# Altium schematic sheet Z27 - LED Digit Board.SchDoc("Z27 - LED Digit Board")
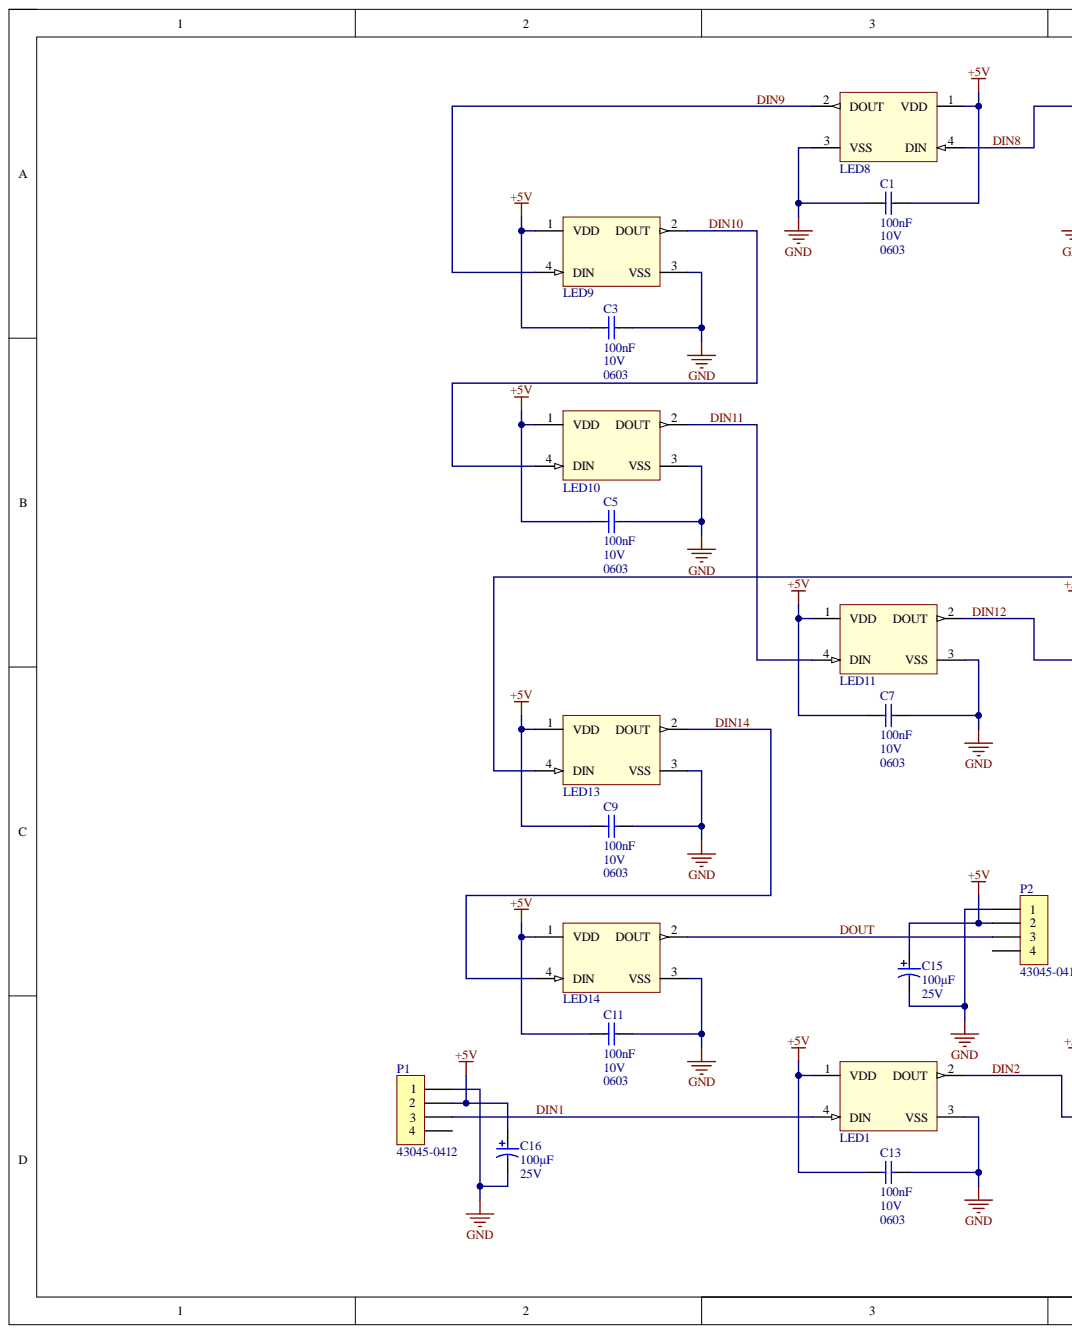
[diagram p0: Z27 - LED Digit Board.SchDoc, left]
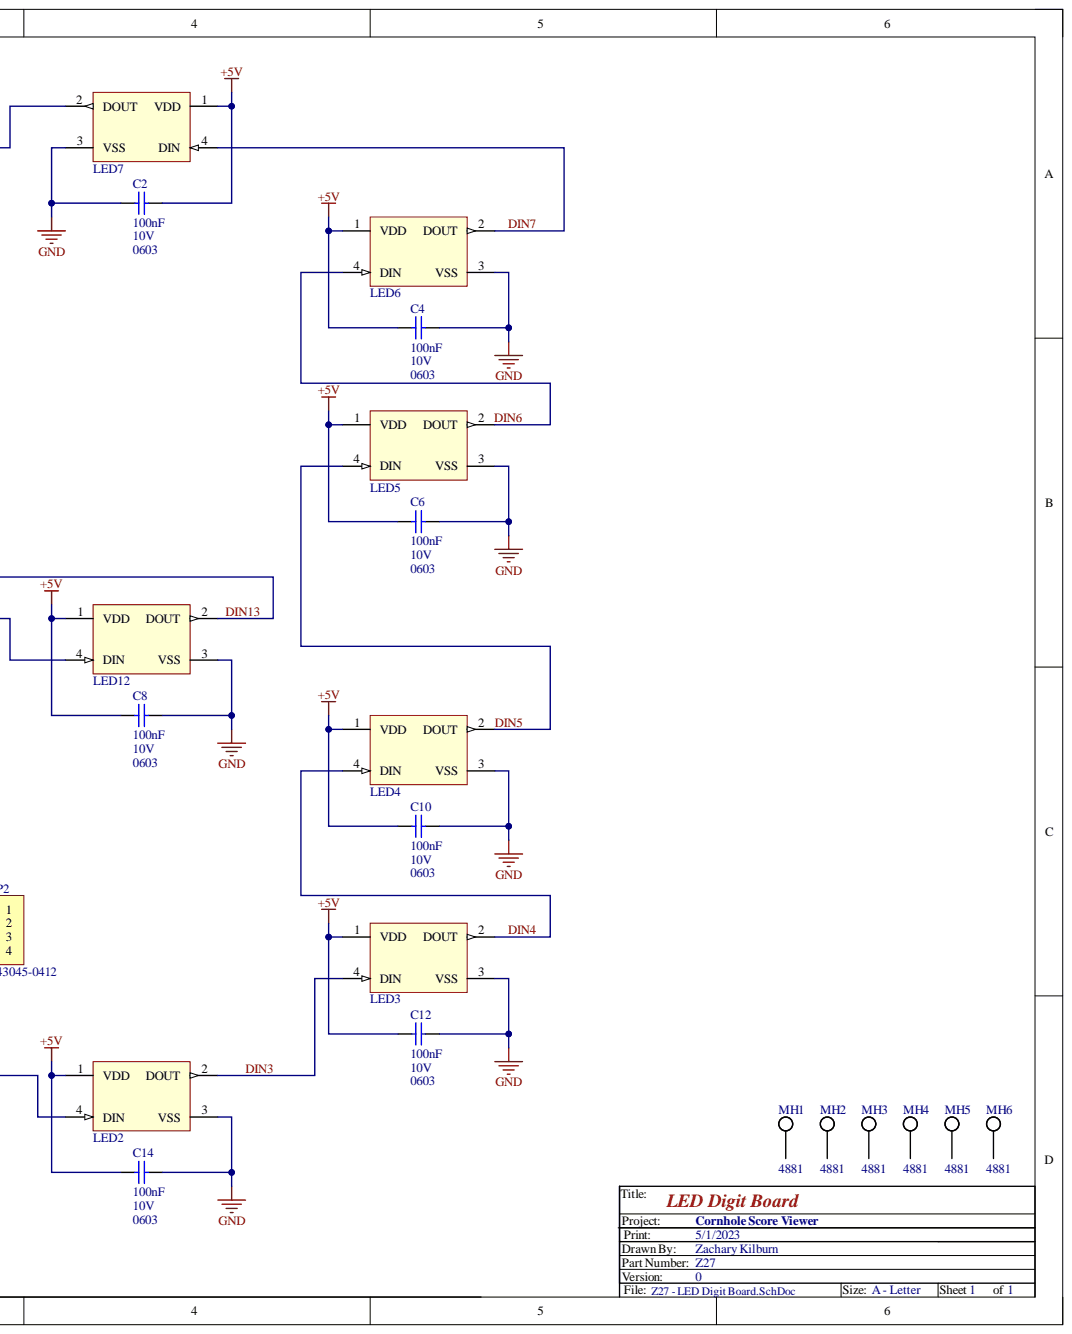
[diagram p1: Z27 - LED Digit Board.SchDoc, right]

## Parts
C1  CAP 100nF 10V 0603(1608)  [PCC-XXX-CAP0603(1608)-100-M]  (value not drawn)
C2  CAP 100nF 10V 0603(1608)  [PCC-XXX-CAP0603(1608)-100-M]  (value not drawn)
C3  CAP 100nF 10V 0603(1608)  [PCC-XXX-CAP0603(1608)-100-M]  (value not drawn)
C4  CAP 100nF 10V 0603(1608)  [PCC-XXX-CAP0603(1608)-100-M]  (value not drawn)
C5  CAP 100nF 10V 0603(1608)  [PCC-XXX-CAP0603(1608)-100-M]  (value not drawn)
C6  CAP 100nF 10V 0603(1608)  [PCC-XXX-CAP0603(1608)-100-M]  (value not drawn)
C7  CAP 100nF 10V 0603(1608)  [PCC-XXX-CAP0603(1608)-100-M]  (value not drawn)
C8  CAP 100nF 10V 0603(1608)  [PCC-XXX-CAP0603(1608)-100-M]  (value not drawn)
C9  CAP 100nF 10V 0603(1608)  [PCC-XXX-CAP0603(1608)-100-M]  (value not drawn)
C10  CAP 100nF 10V 0603(1608)  [PCC-XXX-CAP0603(1608)-100-M]  (value not drawn)
C11  CAP 100nF 10V 0603(1608)  [PCC-XXX-CAP0603(1608)-100-M]  (value not drawn)
C12  CAP 100nF 10V 0603(1608)  [PCC-XXX-CAP0603(1608)-100-M]  (value not drawn)
C13  CAP 100nF 10V 0603(1608)  [PCC-XXX-CAP0603(1608)-100-M]  (value not drawn)
C14  CAP 100nF 10V 0603(1608)  [PCC-XXX-CAP0603(1608)-100-M]  (value not drawn)
C15  value 100µF  Voltage: 25V  [PCC-PANA-CAP-ALUSMD-E-D8.0-H6.5-R]  (hidden comment: EEE-TG1E101UP)
C16  value 100µF  Voltage: 25V  [PCC-PANA-CAP-ALUSMD-E-D8.0-H6.5-R]  (hidden comment: EEE-TG1E101UP)
LED1  (no value)  [PCC-ADA-WS2812B-4L-R]
LED2  (no value)  [PCC-ADA-WS2812B-4L-R]
LED3  (no value)  [PCC-ADA-WS2812B-4L-R]
LED4  (no value)  [PCC-ADA-WS2812B-4L-R]
LED5  (no value)  [PCC-ADA-WS2812B-4L-R]
LED6  (no value)  [PCC-ADA-WS2812B-4L-R]
LED7  (no value)  [PCC-ADA-WS2812B-4L-R]
LED8  (no value)  [PCC-ADA-WS2812B-4L-R]
LED9  (no value)  [PCC-ADA-WS2812B-4L-R]
LED10  (no value)  [PCC-ADA-WS2812B-4L-R]
LED11  (no value)  [PCC-ADA-WS2812B-4L-R]
LED12  (no value)  [PCC-ADA-WS2812B-4L-R]
LED13  (no value)  [PCC-ADA-WS2812B-4L-R]
LED14  (no value)  [PCC-ADA-WS2812B-4L-R]
MH1  4881  [PCC-KEYE-4881-R]
MH2  4881  [PCC-KEYE-4881-R]
MH3  4881  [PCC-KEYE-4881-R]
MH4  4881  [PCC-KEYE-4881-R]
MH5  4881  [PCC-KEYE-4881-R]
MH6  4881  [PCC-KEYE-4881-R]
P1  43045-0412  [PCC-MOLX-43045-0412-R]
P2  43045-0412  [PCC-MOLX-43045-0412-R]

## Nets
+5V: C1.2 C2.2 C3.2 C4.2 C5.2 C6.2 C7.2 C8.2 C9.2 C10.2 C11.2 C12.2 C13.2 C14.2 C15.1 C16.1 LED1.1(VDD) LED2.1(VDD) LED3.1(VDD) LED4.1(VDD) LED5.1(VDD) LED6.1(VDD) LED7.1(VDD) LED8.1(VDD) LED9.1(VDD) LED10.1(VDD) LED11.1(VDD) LED12.1(VDD) LED13.1(VDD) LED14.1(VDD) P1.2 P2.2
GND: C1.1 C2.1 C3.1 C4.1 C5.1 C6.1 C7.1 C8.1 C9.1 C10.1 C11.1 C12.1 C13.1 C14.1 C15.2 C16.2 LED1.3(VSS) LED2.3(VSS) LED3.3(VSS) LED4.3(VSS) LED5.3(VSS) LED6.3(VSS) LED7.3(VSS) LED8.3(VSS) LED9.3(VSS) LED10.3(VSS) LED11.3(VSS) LED12.3(VSS) LED13.3(VSS) LED14.3(VSS) P1.1 P2.1
DIN1: LED1.4(DIN) P1.3
DIN2: LED1.2(DOUT) LED2.4(DIN)
DIN3: LED2.2(DOUT) LED3.4(DIN)
DIN4: LED3.2(DOUT) LED4.4(DIN)
DIN5: LED4.2(DOUT) LED5.4(DIN)
DIN6: LED5.2(DOUT) LED6.4(DIN)
DIN7: LED6.2(DOUT) LED7.4(DIN)
DIN8: LED7.2(DOUT) LED8.4(DIN)
DIN9: LED8.2(DOUT) LED9.4(DIN)
DIN10: LED9.2(DOUT) LED10.4(DIN)
DIN11: LED10.2(DOUT) LED11.4(DIN)
DIN12: LED11.2(DOUT) LED12.4(DIN)
DIN13: LED12.2(DOUT) LED13.4(DIN)
DIN14: LED13.2(DOUT) LED14.4(DIN)
DOUT: LED14.2(DOUT) P2.3

Not connected: MH1 0; MH2 0; MH3 0; MH4 0; MH5 0; MH6 0; P1 4; P2 4

## Library data of the parts
C1-C14 = CMP-010641-1: CAP 100nF 10V ±10% 0603 (1608 Metric) SMD; Tolerence: Â±10%; Component Kind: Standard; Library Name: Generic Capacitors.IntLib; MountingTechnology: Surface Mount
C15 C16 = CMP-015090-0: Capacitor, Aluminum Electrolytic, 100uF, 25V, SMD, Size E; Manufacturer: Panasonic; Ripple Current: 100mA; Component Kind: Standard; ComponentLink1Description: Manufacturer URL; ComponentLink1URL: https://industrial.panasonic.com/; ComponentLink2Description: Product URL; ComponentLink2URL: https://industrial.panasonic.com/ww/products/pt/aluminum-cap-smd/models/EEETG1E101UP; ComponentLink3Description: Datasheet; ComponentLink3URL: https://industrial.panasonic.com/cdbs/www-data/pdf/RDE0000/ABA0000C1187.pdf; ComponentLink4Description: Package Specification; ComponentLink4URL: https://industrial.panasonic.com/cdbs/www-data/pdf/RDE0000/ABA0000C1187.pdf; HelpURL: https://industrial.panasonic.com/ww/products/pt/aluminum-cap-smd/models/EEETG1E101UP; Library Name: Aluminum Electrolytic Capacitors.IntLib; MountingTechnology: Surface Mount; OperatingTemperature: -40C to +125C; PackageName: E; PartNumber: EEE-TG1E101UP
LED1-LED14 = WS2812B: LED, RGB, Addressable, 3.5-5V, 4-SMD; Manufacturer: Worldsemi; Component Kind: Standard; ComponentLink1Description: Manufacturer URL; ComponentLink2Description: Product URL; ComponentLink2URL: https://learn.adafruit.com/adafruit-neopixel-uberguide; ComponentLink3Description: Datasheet; ComponentLink3URL: https://cdn-shop.adafruit.com/datasheets/WS2812B.pdf; ComponentLink4Description: Package Specification; ComponentLink4URL: https://cdn-shop.adafruit.com/datasheets/WS2812B.pdf; HelpURL: https://cdn-learn.adafruit.com/downloads/pdf/adafruit-neopixel-uberguide.pdf; Library Name: LEDs.SchLib; MountingTechnology: Surface Mount; OperatingTemperature: -25C to +80C; PackageName: 4-SMD; PartNumber: WS2812B; Voltage: 3.5-5V
MH1-MH6 = 4881: Spacer, Threaded, Solder, 1/16" Height, #4-40; Manufacturer: Keystone; Between Board Height: 1/16"; Component Kind: Standard; ComponentLink1Description: Manufacturer URL; ComponentLink1URL: https://www.keyelco.com/; ComponentLink2Description: Product URL; ComponentLink2URL: https://www.keyelco.com/product.cfm/product_id/14249; ComponentLink3Description: Datasheet URL; ComponentLink3URL: https://www.keyelco.com/product-pdf.cfm?p=14249; Part Number: 4881; Screw, Thread Size: #4-40; Supplier 1: Digi-Key; Supplier Part Number 1: 36-4881CT-ND; Library Name: Spacers-Standoffs.SchLib
P1 P2 = CMP-000027-0: Molex Micro-Fit 3.0 Connector, Dual-Row, 4-Position, Vertical, 3mm Pitch; Current: 5A; MatingStyle: Plug; Positions: 4; Rows: 2; Voltage: 250V; Component Kind: Standard; ComponentLink1Description: Manufacturer URL; ComponentLink1URL: http://www.molex.com; ComponentLink2Description: Product URL; ComponentLink2URL: http://www.molex.com/molex/products/datasheet.jsp?part=active/0430450412_PCB_HEADERS.xml; ComponentLink3Description: Datasheet; ComponentLink3URL: http://www.molex.com/pdm_docs/ps/PS-43045.pdf; ComponentLink4Description: Package Specification; ComponentLink4URL: http://www.molex.com/pdm_docs/sd/430450412_sd.pdf; HelpURL: http://www.molex.com/pdm_docs/sd/430450412_sd.pdf; Library Name: Molex-Micro-Fit.SchLib; Manufacturer: Molex; MountingTechnology: Through Hole; OperatingTemperature: -40C to +105C; Orientation: Vertical; PackageName: Micro-Fit 3.0; PartNumber: 43045-0412; Pitch: 3mm; RoHS: Yes; StorageTemperature: -40C to +105C
